# Altium schematic sheet dsPic.SchDoc(dsPic)
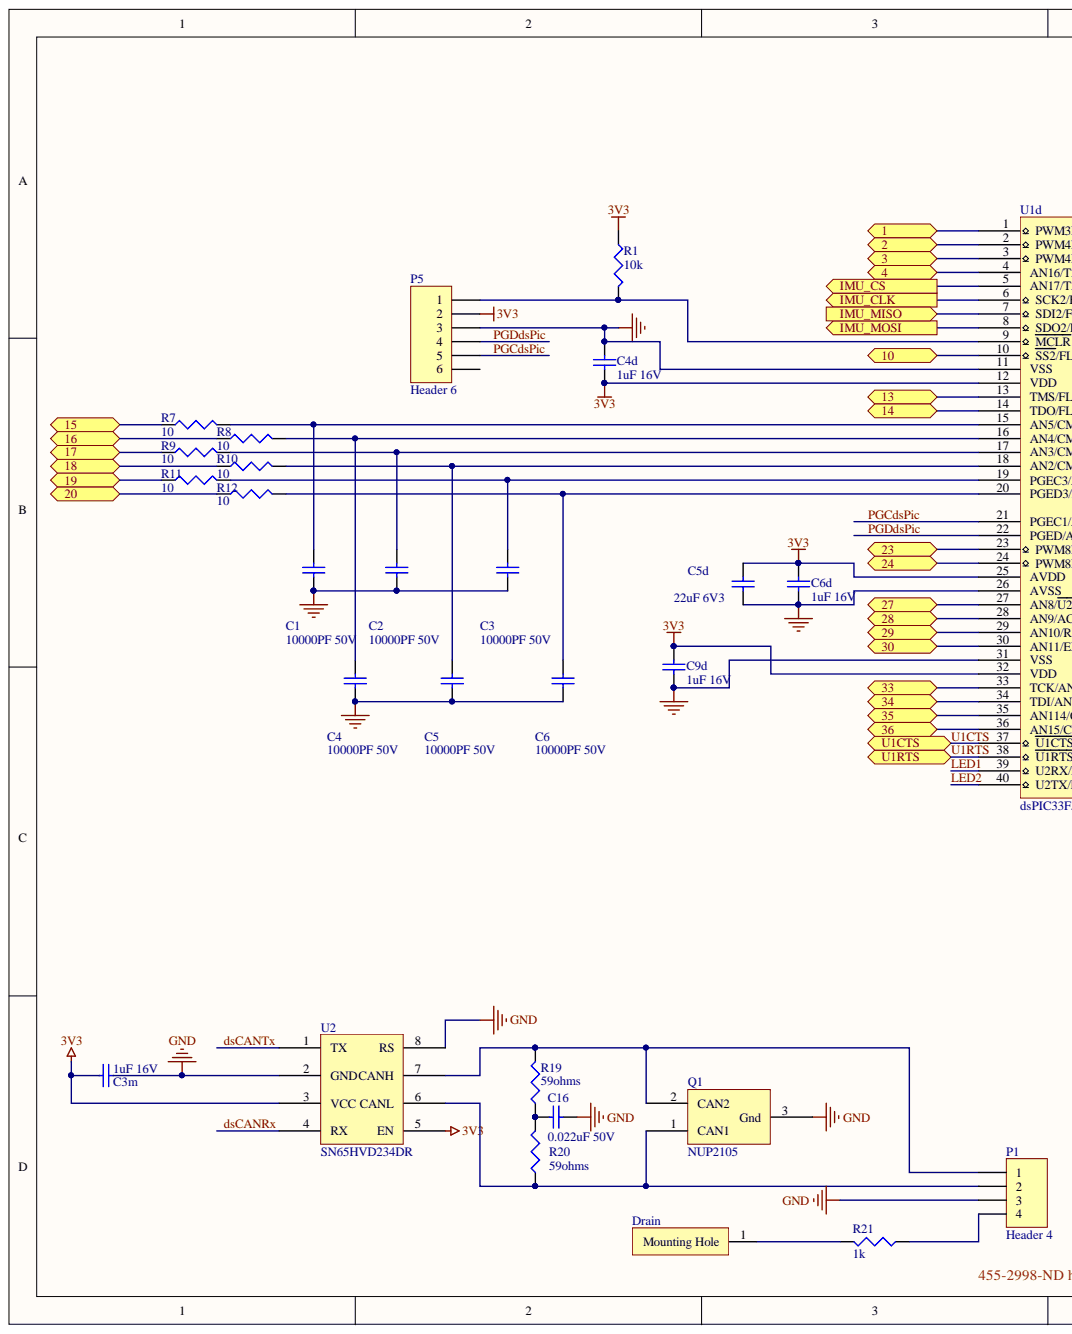
[diagram p0: dsPic.SchDoc, left]
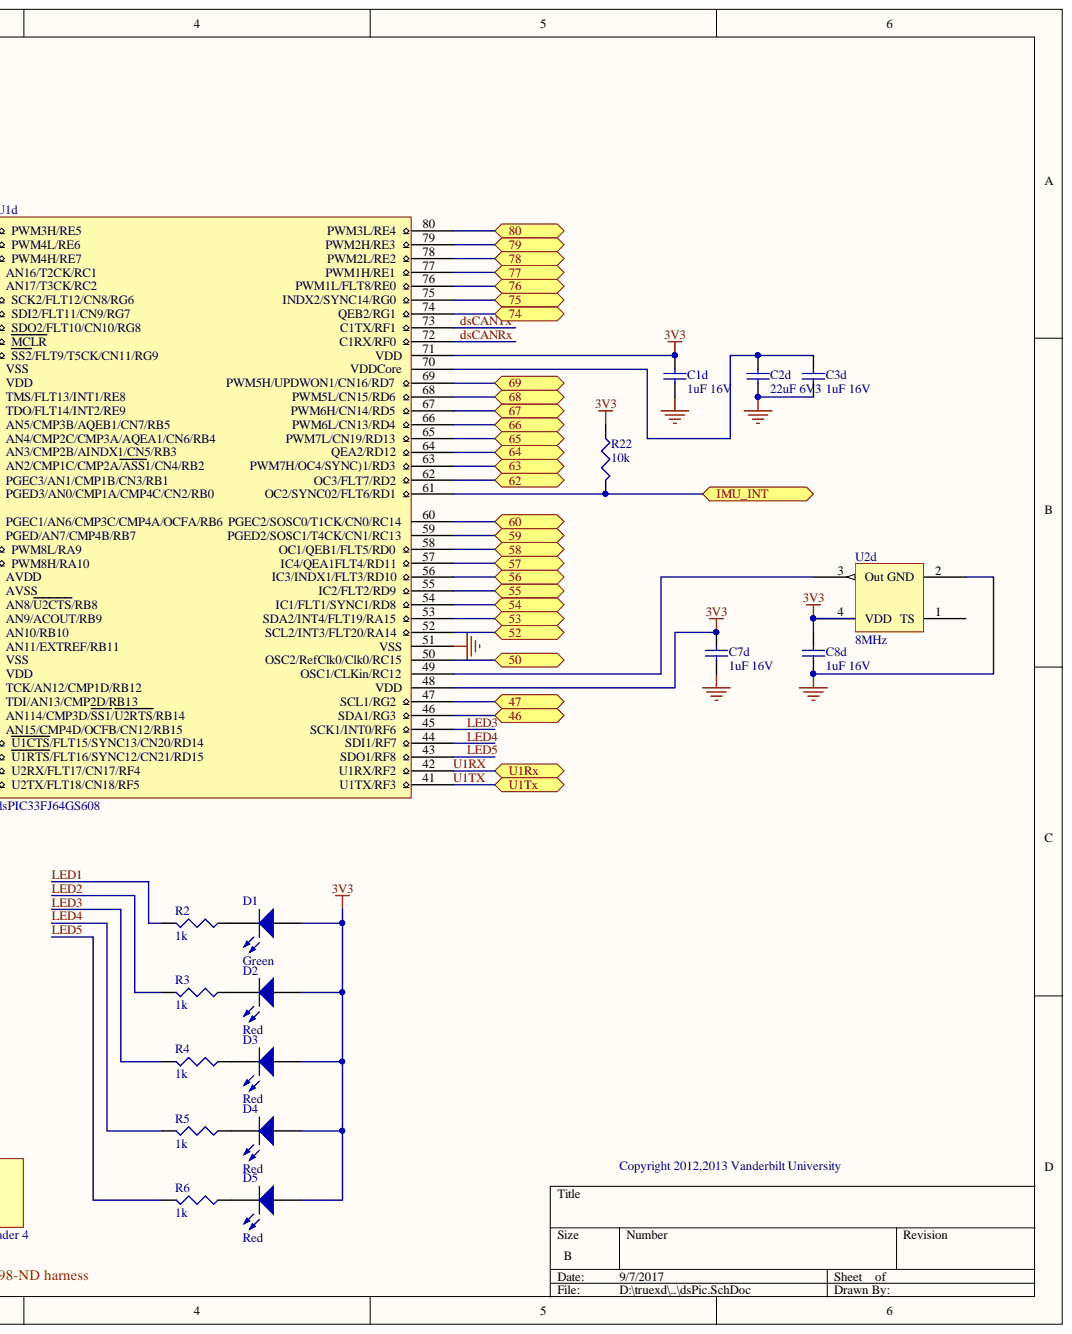
[diagram p1: dsPic.SchDoc, right]

## Parts
C1  10000PF 50V  [RES-0603 - Small]
C1d  1uF 16V  [CAP-0306 - BARE]
C2  10000PF 50V  [RES-0603 - Small]
C2d  22uF 6V3  [2012[0805]]
C3  10000PF 50V  [RES-0603 - Small]
C3d  1uF 16V  [CAP-0306 - BARE]
C3m  1uF 16V  [CAP-0306 - BARE]
C4  10000PF 50V  [RES-0603 - Small]
C4d  1uF 16V  [CAP-0306 - BARE]
C5  10000PF 50V  [RES-0603 - Small]
C5d  22uF 6V3  [2012[0805]]
C6  10000PF 50V  [RES-0603 - Small]
C6d  1uF 16V  [CAP-0306 - BARE]
C7d  1uF 16V  [CAP-0306 - BARE]
C8d  1uF 16V  [CAP-0306 - BARE]
C9d  1uF 16V  [CAP-0306 - BARE]
C16  0.022uF 50V  value 0.022uF  [CAP-0603 - Small]
D1  Green  [LED_0603]
D2  Red  [LED_0603]
D3  Red  [LED_0603]
D4  Red  [LED_0603]
D5  Red  [LED_0603]
Drain  (no value)  [.020 for 28AWG - duplicate]
P1  Header 4  [SM04B-SRSS]
P5  Header 6  [6x1 Pin Header]
Q1  NUP2105  [SOT23-3M]
R1  10k  [RES-0603 - Small]
R2  1k  [RES-0603 - Small]
R3  1k  [RES-0603 - Small]
R4  1k  [RES-0603 - Small]
R5  1k  [RES-0603 - Small]
R6  1k  [RES-0603 - Small]
R7  10  [RES-0603 - Small]
R8  10  [RES-0603 - Small]
R9  10  [RES-0603 - Small]
R10  10  [RES-0603 - Small]
R11  10  [RES-0603 - Small]
R12  10  [RES-0603 - Small]
R19  59ohms  [RES-0603 - Small]
R20  59ohms  [RES-0603 - Small]
R21  1k  [RES-1206]
R22  10k  [RES-0603 - Small]
U1d  dsPIC33FJ64GS608  [TQFP-80]
U2  SN65HVD234DR  [SOIC-8M]
U2d  8MHz  [OSC - small]

## Ports
1, 2, 3, 4, 10, 13, 14, 15, 16, 17, 18, 19, 20, 23, 24, 27, 28, 29, 30, 33, 34, 35, 36, 46, 47, 50, 52, 53, 54, 55, 56, 57, 58, 59, 60, 62, 63, 64, 65, 66, 67, 68, 69, 74, 75, 76, 77, 78, 79, 80, IMU_CLK, IMU_CS, IMU_INT, IMU_MISO, IMU_MOSI, U1CTS, U1RTS, U1Rx, U1Tx

## Nets
3V3: C1d.2 C3m.1 C4d.2 C5d.2 C6d.2 C7d.2 C8d.2 C9d.2 D1.1 D2.1 D3.1 D4.1 D5.1 P5.2 R1.1 R22.1 U1d.12(VDD) U1d.25(AVDD) U1d.32(VDD) U1d.48(VDD) U1d.71(VDD) U2.3(VCC) U2.5(EN) U2d.4(VDD)
GND: C1.1 C1d.1 C2.1 C2d.1 C3.1 C3d.1 C3m.2 C4.1 C4d.1 C5.1 C5d.1 C6.1 C6d.1 C7d.1 C8d.1 C9d.1 C16.1 P1.3 P5.3 Q1.3 U1d.11(VSS) U1d.26(AVSS) U1d.31(VSS) U1d.51(VSS) U2.2(GND) U2.8(RS) U2d.2(GND)
1: U1d.1(PWM3H/RE5) ; port 1
2: U1d.2(PWM4L/RE6) ; port 2
3: U1d.3(PWM4H/RE7) ; port 3
4: U1d.4(AN16/T2CK/RC1) ; port 4
10: U1d.10(SS2/FLT9/T5CK/CN11/RG9) ; port 10
13: U1d.13(TMS/FLT13/INT1/RE8) ; port 13
14: U1d.14(TDO/FLT14/INT2/RE9) ; port 14
15: R7.1 ; port 15
16: R8.1 ; port 16
17: R9.1 ; port 17
18: R10.1 ; port 18
19: R11.1 ; port 19
20: R12.1 ; port 20
23: U1d.23(PWM8L/RA9) ; port 23
24: U1d.24(PWM8H/RA10) ; port 24
27: U1d.27(AN8/U2CTS/RB8) ; port 27
28: U1d.28(AN9/ACOUT/RB9) ; port 28
29: U1d.29(AN10/RB10) ; port 29
30: U1d.30(AN11/EXTREF/RB11) ; port 30
33: U1d.33(TCK/AN12/CMP1D/RB12) ; port 33
34: U1d.34(TDI/AN13/CMP2D/RB13) ; port 34
35: U1d.35(AN114/CMP3D/SS1/U2RTS/RB14) ; port 35
36: U1d.36(AN15/CMP4D/OCFB/CN12/RB15) ; port 36
46: U1d.46(SDA1/RG3) ; port 46
47: U1d.47(SCL1/RG2) ; port 47
50: U1d.50(OSC2/RefClk0/Clk0/RC15) ; port 50
52: U1d.52(SCL2/INT3/FLT20/RA14) ; port 52
53: U1d.53(SDA2/INT4/FLT19/RA15) ; port 53
54: U1d.54(IC1/FLT1/SYNC1/RD8) ; port 54
55: U1d.55(IC2/FLT2/RD9) ; port 55
56: U1d.56(IC3/INDX1/FLT3/RD10) ; port 56
57: U1d.57(IC4/QEA1FLT4/RD11) ; port 57
58: U1d.58(OC1/QEB1/FLT5/RD0) ; port 58
59: U1d.59(PGED2/SOSC1/T4CK/CN1/RC13) ; port 59
60: U1d.60(PGEC2/SOSC0/T1CK/CN0/RC14) ; port 60
62: U1d.62(OC3/FLT7/RD2) ; port 62
63: U1d.63(PWM7H/OC4/SYNC)1/RD3) ; port 63
64: U1d.64(QEA2/RD12) ; port 64
65: U1d.65(PWM7L/CN19/RD13) ; port 65
66: U1d.66(PWM6L/CN13/RD4) ; port 66
67: U1d.67(PWM6H/CN14/RD5) ; port 67
68: U1d.68(PWM5L/CN15/RD6) ; port 68
69: U1d.69(PWM5H/UPDWON1/CN16/RD7) ; port 69
74: U1d.74(QEB2/RG1) ; port 74
75: U1d.75(INDX2/SYNC14/RG0) ; port 75
76: U1d.76(PWM1L/FLT8/RE0) ; port 76
77: U1d.77(PWM1H/RE1) ; port 77
78: U1d.78(PWM2L/RE2) ; port 78
79: U1d.79(PWM2H/RE3) ; port 79
80: U1d.80(PWM3L/RE4) ; port 80
dsCANRx: U1d.72(C1RX/RF0) U2.4(RX)
dsCANTx: U1d.73(C1TX/RF1) U2.1(TX)
IMU_CLK: U1d.6(SCK2/FLT12/CN8/RG6) ; port IMU_CLK
IMU_CS: U1d.5(AN17/T3CK/RC2) ; port IMU_CS
IMU_INT: R22.2 U1d.61(OC2/SYNC02/FLT6/RD1) ; port IMU_INT
IMU_MISO: U1d.7(SDI2/FLT11/CN9/RG7) ; port IMU_MISO
IMU_MOSI: U1d.8(SDO2/FLT10/CN10/RG8) ; port IMU_MOSI
LED1: R2.2 U1d.39(U2RX/FLT17/CN17/RF4)
LED2: R3.2 U1d.40(U2TX/FLT18/CN18/RF5)
LED3: R4.2 U1d.45(SCK1/INT0/RF6)
LED4: R5.2 U1d.44(SDI1/RF7)
LED5: R6.2 U1d.43(SDO1/RF8)
PGCdsPic: P5.5 U1d.21(PGEC1/AN6/CMP3C/CMP4A/OCFA/RB6)
PGDdsPic: P5.4 U1d.22(PGED/AN7/CMP4B/RB7)
U1CTS: U1d.37(U1CTS/FLT15/SYNC13/CN20/RD14) ; port U1CTS
U1RTS: U1d.38(U1RTS/FLT16/SYNC12/CN21/RD15) ; port U1RTS
U1RX: U1d.42(U1RX/RF2) ; port U1Rx
U1TX: U1d.41(U1TX/RF3) ; port U1Tx
NetC1_2: C1.2 R7.2 U1d.15(AN5/CMP3B/AQEB1/CN7/RB5)
NetC2_2: C2.2 R9.2 U1d.17(AN3/CMP2B/AINDX1/CN5/RB3)
NetC2d_2: C2d.2 C3d.2 U1d.70(VDDCore)
NetC3_2: C3.2 R11.2 U1d.19(PGEC3/AN1/CMP1B/CN3/RB1)
NetC4_2: C4.2 R8.2 U1d.16(AN4/CMP2C/CMP3A/AQEA1/CN6/RB4)
NetC5_2: C5.2 R10.2 U1d.18(AN2/CMP1C/CMP2A/ASS1/CN4/RB2)
NetC6_2: C6.2 R12.2 U1d.20(PGED3/AN0/CMP1A/CMP4C/CN2/RB0)
NetC16_2: C16.2 R19.1 R20.2
NetD1_2: D1.2 R2.1
NetD2_2: D2.2 R3.1
NetD3_2: D3.2 R4.1
NetD4_2: D4.2 R5.1
NetD5_2: D5.2 R6.1
NetDrain_1: Drain.1 R21.2
NetP1_1: P1.1 Q1.2 R19.2 U2.7(CANH)
NetP1_2: P1.2 Q1.1 R20.1 U2.6(CANL)
NetP1_4: P1.4 R21.1
NetP5_1: P5.1 R1.2 U1d.9(MCLR)
NetU1d_49: U1d.49(OSC1/CLKin/RC12) U2d.3(Out)

Not connected: P5 6; U2d 1(TS)

## Library data of the parts
C1-C6 = Cap Semi: CAP CER 10000PF 50V X7R 0603; Part Number: CC0603KRX7R9BB103; Manufacturer: AVX; Location: 1; Simulation: CAP; Signal Integrity: Cap Semi; Manufacturer Part #: CC0603KRX7R9BB103; Library Name: Miscellaneous Devices.IntLib; Digikey: 311-1085-1-ND; Cost: 0.05; Component Kind: Standard
C1d C3d C4d C6d C7d C9d = Cap Semi: CAP CER 1UF 16V X5R 0306; Part Number: C0816X5R1C105M050AC; Manufacturer: TDK Corporation; Location: 1; Simulation: CAP; Signal Integrity: Cap Semi; Manufacturer Part #: C0816X5R1C105M050AC; Digikey: 445-13750-1-ND; Cost: .52; Component Kind: Standard; Library Name: rleg.IntLib
C2d C5d = Cap Semi: CAP CER 22UF 10V 20% X5R 0805; Part Number: C0805C226M9PACTU; Manufacturer: TDK; Location: 3; Simulation: CAP; Signal Integrity: Cap Semi; Manufacturer Part #: C0805C226M9PACTU; Library Name: Miscellaneous Devices.IntLib; Digikey: 399-4696-1-ND; Cost: 0.45; Component Kind: Standard
C3m = cap: CAP CER 1UF 16V X5R 0306; Part Number: C0816X5R1C105M050AC; Manufacturer: TDK Corporation; Location: 1; Manufacturer Part #: C0816X5R1C105M050AC; Digikey: 445-13750-1-ND; Cost: .52; Component Kind: Standard; Library Name: rleg.IntLib
C8d = Cap Semi: CAP CER 1UF 16V X5R 0306; Part Number: C0816X5R1C105M050AC; Manufacturer: TDK Corporation; Location: 1; Do Not Load: DNL; Simulation: CAP; Signal Integrity: Cap Semi; Manufacturer Part #: C0816X5R1C105M050AC; Digikey: 445-13750-1-ND; Cost: .52; Component Kind: Standard; Library Name: rleg.IntLib
C16 = Cap Semi: CAP CER 0.022UF 50V X7R 0603; Part Number: C1608X7R1H223K080AA; Manufacturer: TDK; Budgetary Price: 0.10; Simulation: CAP; Signal Integrity: Cap Semi; Primary Supplier Part #: 445-1312-1-ND; Primary Supplier: Digi-Key; PackageDescription: 0603; Manufacturer Part #: C1608X7R1H223K080AA; Library Name: Miscellaneous Devices.IntLib; Digikey: 445-1312-1-ND; Component Kind: Standard
D1 = LED3: LED GREEN CLEAR 0603 SMD; Part Number: LTST-C191KGKT; Manufacturer: Lite-On Inc.; Location: 2; Simulation: LED3; Manufacturer Part #: LTST-C191KGKT; Library Name: Miscellaneous Devices.IntLib; Digikey: 160-1446-1-ND; Cost: 0.29; Component Kind: Standard
D2-D5 = LED3: LED RED CLEAR 0603 SMD; Part Number: LTST-C191KRKT; Manufacturer: Lite-On Inc.; Location: 2; Simulation: LED3; Manufacturer Part #: LTST-C191KRKT; Library Name: Miscellaneous Devices.IntLib; Digikey: 160-1447-1-ND; Cost: 0.16; Component Kind: Standard
Drain = Mounting Hole: Budgetary Price: .15; Component Kind: Standard; Library Name: rleg.SchLib
P1 = Header 4: Header, 4-Pin; Part Number: SM04B-SRSS-TB(LF)(SN); Manufacturer: JST Sales America Inc.; Signal Integrity: Connector; Manufacturer Part #: SM04B-SRSS-TB(LF)(SN); Library Name: Miscellaneous Connectors.IntLib; LatestRevisionNote: Re-released for DXP Platform.; LatestRevisionDate: 17-Jul-2002; Digikey: 455-1804-1-ND; Cost: 0.61; Component Kind: Standard; Publisher: Altium Limited
P5 = Header 6: CONN HEADER 6POS .100 STR TIN; Part Number: 68000-406HLF; Manufacturer: FCI; Signal Integrity: Connector; Manufacturer Part #: 68000-406HLF; Library Name: Miscellaneous Connectors.IntLib; LatestRevisionNote: Re-released for DXP Platform.; LatestRevisionDate: 17-Jul-2002; Digikey: 609-3263-ND; Cost: .25; Component Kind: Standard; Publisher: Altium Limited
Q1 = NUP2105: TVS DIODE 24VWM 44VC SOT23; Part Number: NUP1105LT1G; Manufacturer: ON Semiconductor; Budgetary Price: 0.48; Primary Supplier Part #: NUP1105LT1GOSCT-ND; Primary Supplier: Digi-Key; PackageDescription: SOT-23; Manufacturer Part #: NUP1105LT1G; Digikey: NUP1105LT1GOSCT-ND; Cost: 0.48; Component Kind: Standard; Library Name: rleg.SchLib
R1 R22 = Res3: RES SMD 10K OHM 1% 1/10W 0603; Part Number: RC0603FR-0710KL; Manufacturer: Yageo; Location: 1; Simulation: RESISTOR; Signal Integrity: Res; Manufacturer Part #: RC0603FR-0710KL; Library Name: Miscellaneous Devices.IntLib; Digikey: 311-10.0KHRCT-ND; Cost: 0.10; Component Kind: Standard
R2-R6 = Res3: RES SMD 1K OHM 1% 1/10W 0603; Part Number: RC0603FR-071KL; Manufacturer: Yageo; Location: 1; Simulation: RESISTOR; Signal Integrity: Res; Library Name: Miscellaneous Devices.IntLib; Digikey: 311-1.00KHRCT-ND; Cost: 0.03; Component Kind: Standard
  R3-R6: Manufacturer Part #: RC0603FR-071KL
R7-R12 = Res3: RES SMD 10 OHM 1% 1/10W 0603; Part Number: RC0603FR-0710RL; Manufacturer: Yageo; Simulation: RESISTOR; Signal Integrity: Res; Manufacturer Part #: RC0603FR-0710RL; Library Name: Miscellaneous Devices.IntLib; Digikey: 311-10.0HRCT-ND; Cost: 0.10; Component Kind: Standard
R19 R20 = Res3: Resistor 120Ohm 1/10W 1% TC+/-100ppm; Part Number: RC0603FR-0759RL; Manufacturer: Yageo; Budgetary Price: 0.02; Value: 59ohms; Simulation: RESISTOR; Signal Integrity: Res; Primary Supplier Part #: P59.0HCT-ND; Primary Supplier: Digi-Key; PackageDescription: 0603 (1608M); Manufacturer Part #: RC0603FR-0759RL; Library Name: Miscellaneous Devices.IntLib; Digikey: 311-59.0HRCT-ND; Cost: 0.10; Component Kind: Standard
R21 = Res3: RES SMD 1K OHM 1% 1/4W 1206; Part Number: RC1206FR-071KL; Manufacturer: Yageo; Location: 1; Simulation: RESISTOR; Signal Integrity: Res; Manufacturer Part #: RC1206FR-071KL; Library Name: Miscellaneous Devices.IntLib; Digikey: 311-1.00KFRCT-ND; Cost: 0.03; Component Kind: Standard
U1d = dsPIC33FJ64GS608: DSPIC33FJ64GS608-I/PT-ND; Location: 4; Part Number: DSPIC33FJ64GS608-I/PT-ND; Mouser Part Number: 579-33FJ64GS608-I/PT; Manufacturer Part #: DSPIC33FJ64GS608-I/PT-ND; Digikey: DSPIC33FJ64GS608-I/PT-ND; Cost: 7.60; Component Kind: Standard; Library Name: rleg.IntLib
U2 = SN65HVD234DR: IC CAN TRANSCEIVER 3.3V 8-SOIC; Manufacturer: Texas Instruments; Part Number: SN65HVD234DR; Manufacturer Part #: SN65HVD234DR; Digikey: 296-27991-1-ND; Cost: 2.73; Component Kind: Standard; Library Name: rleg.SchLib
U2d = ECS_20xx_Oscillator: OSC XO 8.000MHZ HCMOS SMD; Part Number: ECS-2033-080-BN; Location: 4; Do Not Load: DNL; Digikey: XC1424CT-ND; Cost: 7.43; Component Kind: Standard; Library Name: rleg.IntLib
